# Altium schematic sheet C1804 FreqCounter - Power (REV04).SchDoc
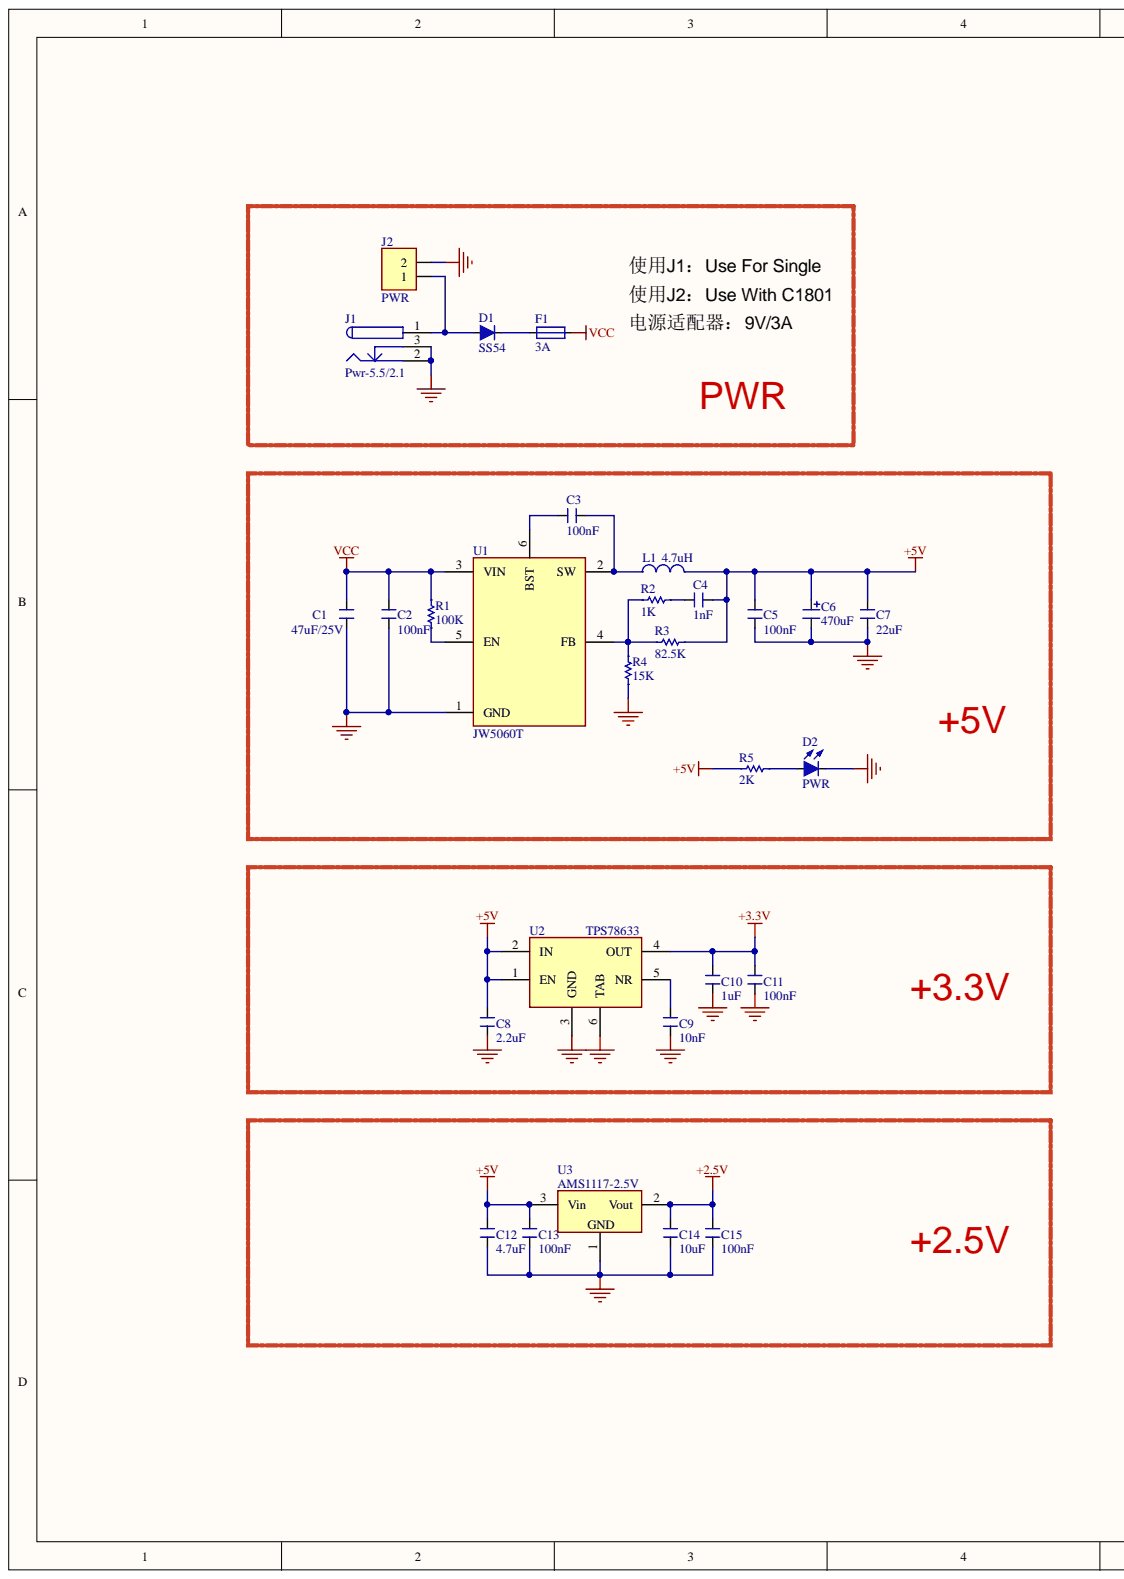
[diagram p0: C1804 FreqCounter - Power (REV04).SchDoc, left]
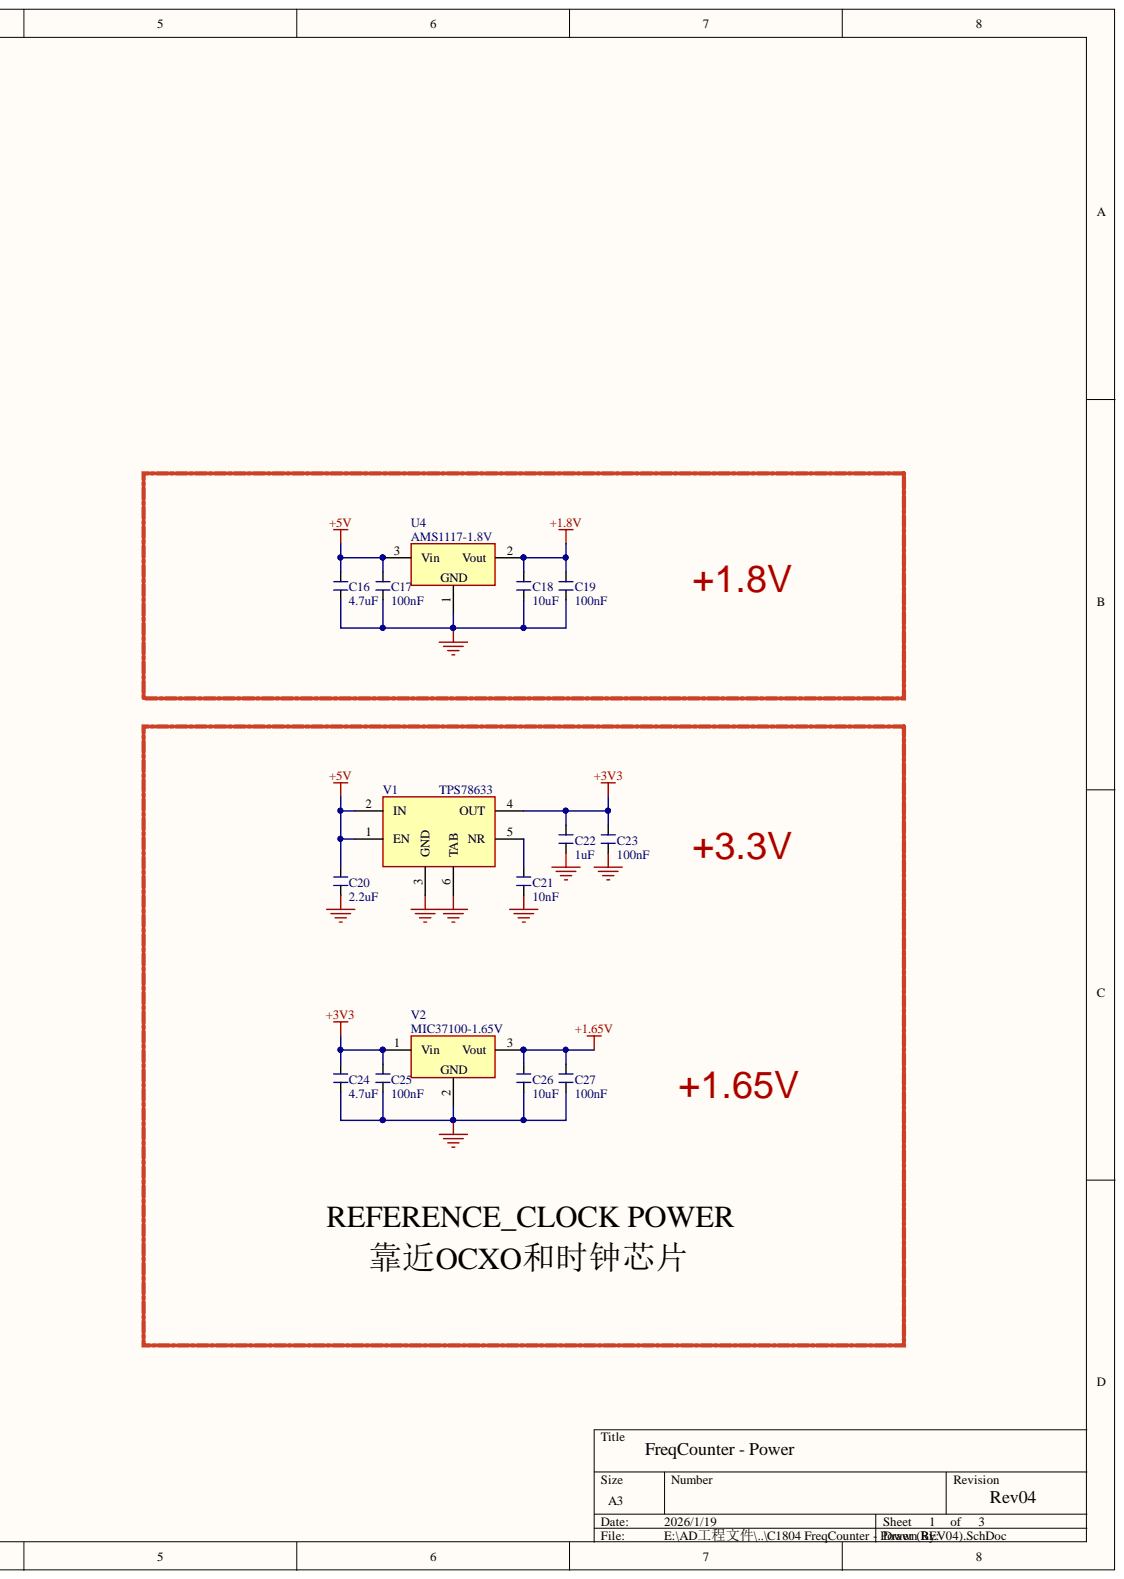
[diagram p1: C1804 FreqCounter - Power (REV04).SchDoc, right]

## Parts
C1  47uF/25V  [C-1206]
C2  100nF  [C-0603]
C3  100nF  [C-0603]
C4  1nF  [C-0603]
C5  100nF  [C-0603]
C6  470uF  [CAP-SMD (D=6.3MM,L=7.7MM)]
C7  22uF  [C-1206]
C8  2.2uF  [C-0805]
C9  10nF  [C-0603]
C10  1uF  [C-0603]
C11  100nF  [C-0603]
C12  4.7uF  [C-0805]
C13  100nF  [C-0603]
C14  10uF  [C-0805]
C15  100nF  [C-0603]
C16  4.7uF  [C-0805]
C17  100nF  [C-0603]
C18  10uF  [C-0805]
C19  100nF  [C-0603]
C20  2.2uF  [C-0805]
C21  10nF  [C-0603]
C22  1uF  [C-0603]
C23  100nF  [C-0603]
C24  4.7uF  [C-0805]
C25  100nF  [C-0603]
C26  10uF  [C-0805]
C27  100nF  [C-0603]
D1  SS54  [DO-214AC (SMA)]
D2  PWR  [LED-0805]
F1  3A  [F1808_SOCKET]
J1  Pwr-5.5/2.1  [PwrJack_5521]
J2  PWR  [AMP5.08-2P]
L1  4.7uH  [L-SMD (L=5.4,W5.2)]
R1  100K  [R-0603]
R2  1K  [R-0603]
R3  82.5K  [R-0603]
R4  15K  [R-0603]
R5  2K  [R-0603]
U1  JW5060T  [TSOT23-6 (2.9*1.6,P=0.95MM)]
U2  TPS78633  [SOT223-6]
U3  AMS1117-2.5V  [SOT-223]
U4  AMS1117-1.8V  [SOT-223]
V1  TPS78633  [SOT223-6]
V2  MIC37100-1.65V  [SOT-223]

## Nets
+1.8V: C18.1 C19.1 U4.2
+1.65V: C26.1 C27.1 V2.3
+2.5V: C14.1 C15.1 U3.2
+3.3V: C10.1 C11.1 U2.4(OUT)
+3V3: C22.1 C23.1 C24.1 C25.1 V1.4(OUT) V2.1
+5V: C4.1 C5.2 C6.1 C7.2 C8.1 C12.1 C13.1 C16.1 C17.1 C20.1 L1.2 R3.2 R5.2 U2.1(EN) U2.2(IN) U3.3 U4.3 V1.1(EN) V1.2(IN)
GND: C1.1 C2.2 C5.1 C6.2 C7.1 C8.2 C9.2 C10.2 C11.2 C12.2 C13.2 C14.2 C15.2 C16.2 C17.2 C18.2 C19.2 C20.2 C21.2 C22.2 C23.2 C24.2 C25.2 C26.2 C27.2 D2.K J1.2 J1.3 J2.2 R4.1 U1.1(GND) U2.3(GND) U2.6(TAB) U3.1 U4.1 V1.3(GND) V1.6(TAB) V2.2
VCC: C1.2 C2.1 F1.2 R1.1 U1.3(VIN)
NetC3_1: C3.1 U1.6(BST)
NetC3_2: C3.2 L1.1 U1.2(SW)
NetC4_2: C4.2 R2.2
NetC9_1: C9.1 U2.5(NR)
NetC21_1: C21.1 V1.5(NR)
NetD1_A: D1.A J1.1 J2.1
NetD1_K: D1.K F1.1
NetD2_A: D2.A R5.1
NetR1_2: R1.2 U1.5(EN)
NetR2_1: R2.1 R3.1 R4.2 U1.4(FB)

## Library data of the parts
C1-C5 C7-C27 = CAP: Component Kind: Standard; Library Name: HW SCH Lib.SCHLIB
C6 = Cap Pol: Polarized Capacitor (Surface Mount); Value: 10uF; Component Kind: Standard; LatestRevisionDate: 17-Jul-2002; LatestRevisionNote: Re-released for DXP Platform.; Library Name: HW SCH Lib.SCHLIB; PackageReference: C0805; Published: 8-Jun-2000; Publisher: Altium Limited
D1 = Diode: 1N4007; 1N4148; Code_JEDEC: DO-204-AL; Component Kind: Standard; ComponentLink1Description: Manufacturer Link; ComponentLink1URL: http://www.fairchildsemi.com/; ComponentLink2Description: Datasheet; ComponentLink2URL: http://www.fairchildsemi.com/ds/1N%2F1N4001.pdf; DatasheetDocument: 1998; LatestRevisionDate: 17-Jul-2002; LatestRevisionNote: Re-released for DXP Platform.; Library Name: HW SCH Lib.SCHLIB; PackageDocument: Aug-1999; PackageReference: DO-41; Published: 8-Jun-2000; Publisher: Altium Limited
D2 = Diode LED: Typical RED, GREEN, YELLOW, AMBER GaAs LED; Component Kind: Standard; LatestRevisionDate: 17-Jul-2002; LatestRevisionNote: Re-released for DXP Platform.; Library Name: HW SCH Lib.SCHLIB; PackageDocument: Feb-2002; PackageReference: 3.2X1.6X1.1; Published: 8-Jun-2000; Publisher: Altium Limited
F1 = Fuse 1: Fuse; Component Kind: Standard; LatestRevisionDate: 17-Jul-2002; LatestRevisionNote: Re-released for DXP Platform.; Library Name: HW SCH Lib.SCHLIB; PackageReference: PIN-W2/E2.8; Published: 8-Jun-2000; Publisher: Altium Limited
J1 = Pwr-5.5/2.1: Low Voltage Power Supply Connector; Component Kind: Standard; Library Name: HW SCH Lib.SCHLIB
J2 = CON2: Component Kind: Standard; Library Name: HW SCH Lib.SCHLIB
L1 = Inductor: Component Kind: Standard; Library Name: HW SCH Lib.SCHLIB
R1-R5 = RES: : 10K; Component Kind: Standard; Library Name: HW SCH Lib.SCHLIB
U1 = JW5060T: DCDC; VIN=4V~24V; IOUT=3A;; Component Kind: Standard; Library Name: HW SCH Lib.SCHLIB
U2 V1 = TPS78633: LDO; VIN_MAX=5.5V; VOUT=3.3V; IOUT=1.5A;; Component Kind: Standard; Library Name: HW SCH Lib.SCHLIB
U3 U4 = AMS1117: LDO; VOUT=1.2V/1.8V/2.5V/2.85V/3.3V/5.0V; IOUTMAX=800mA;; Component Kind: Standard; Library Name: HW SCH Lib.SCHLIB
V2 = MIC37100: LDO; VOUT=1.5V/1.65V/1.8V/2.5V/3.3V;VIN=6V; IOUT=1A;; Component Kind: Standard; Library Name: HW SCH Lib.SCHLIB
